# Altium schematic sheet DAP_LINK.SchDoc
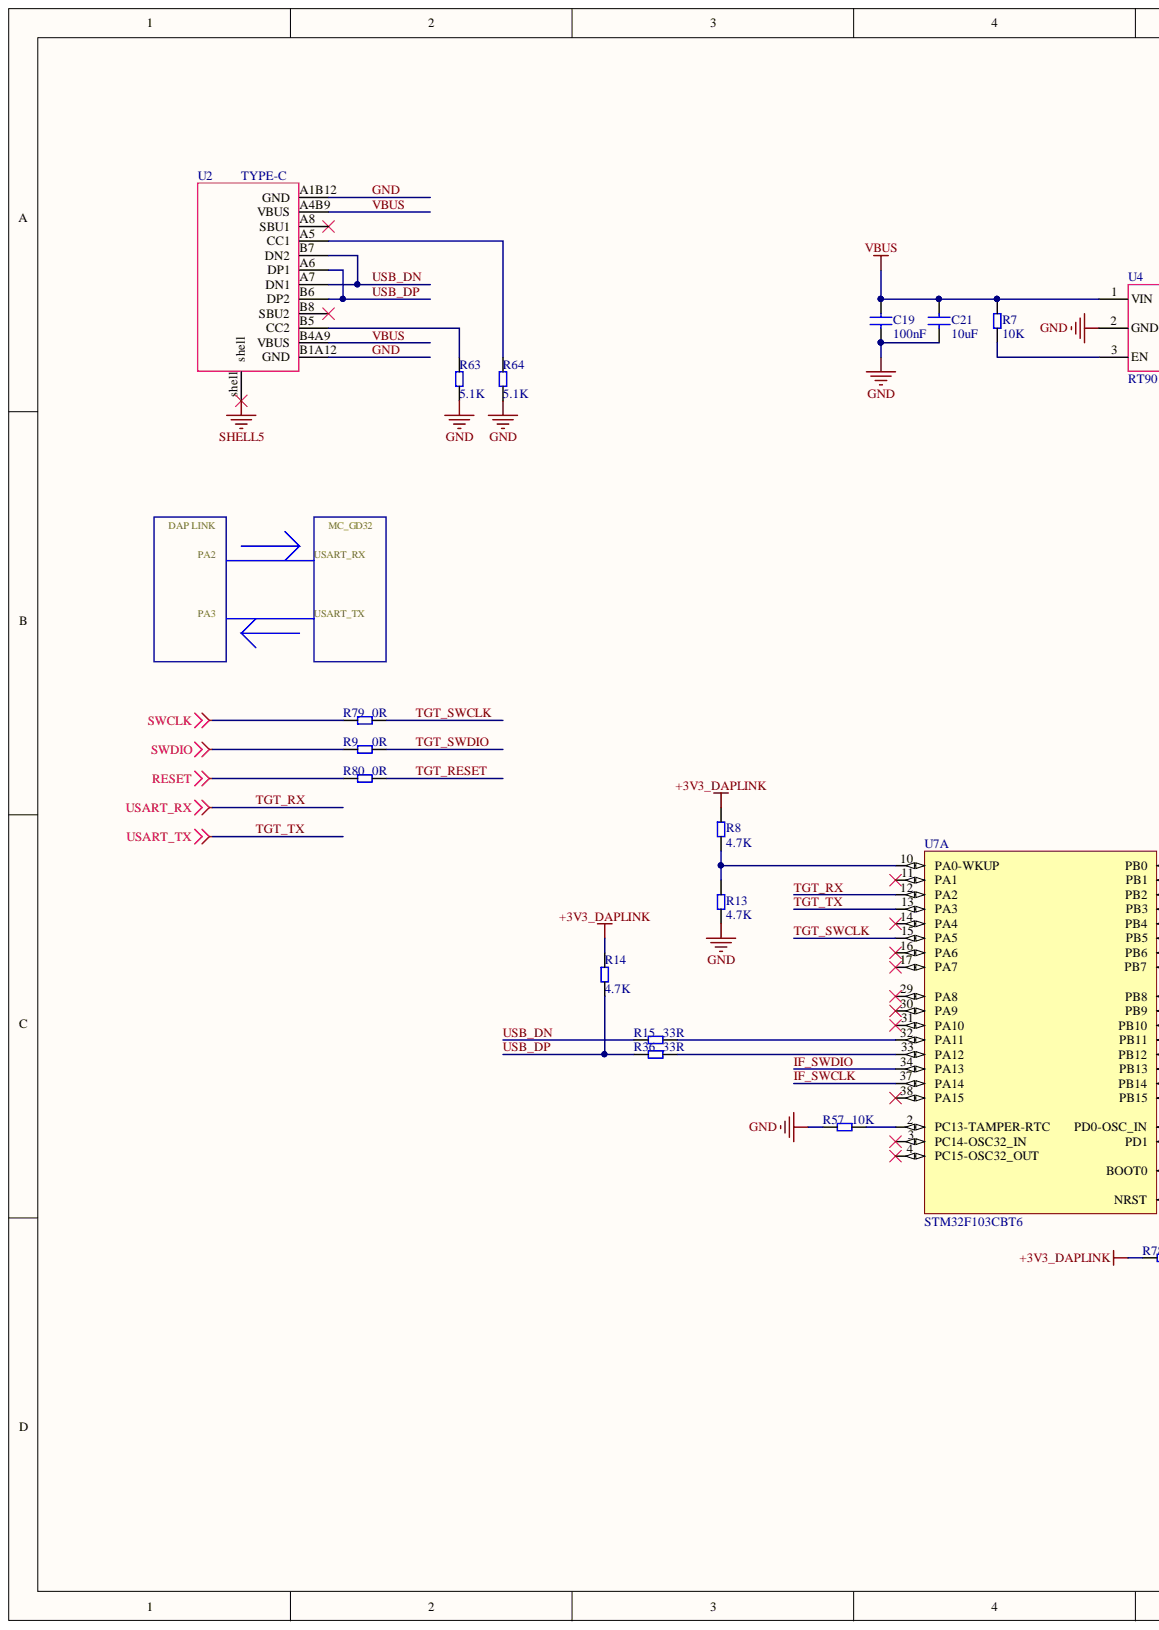
[diagram p0: DAP_LINK.SchDoc, left]
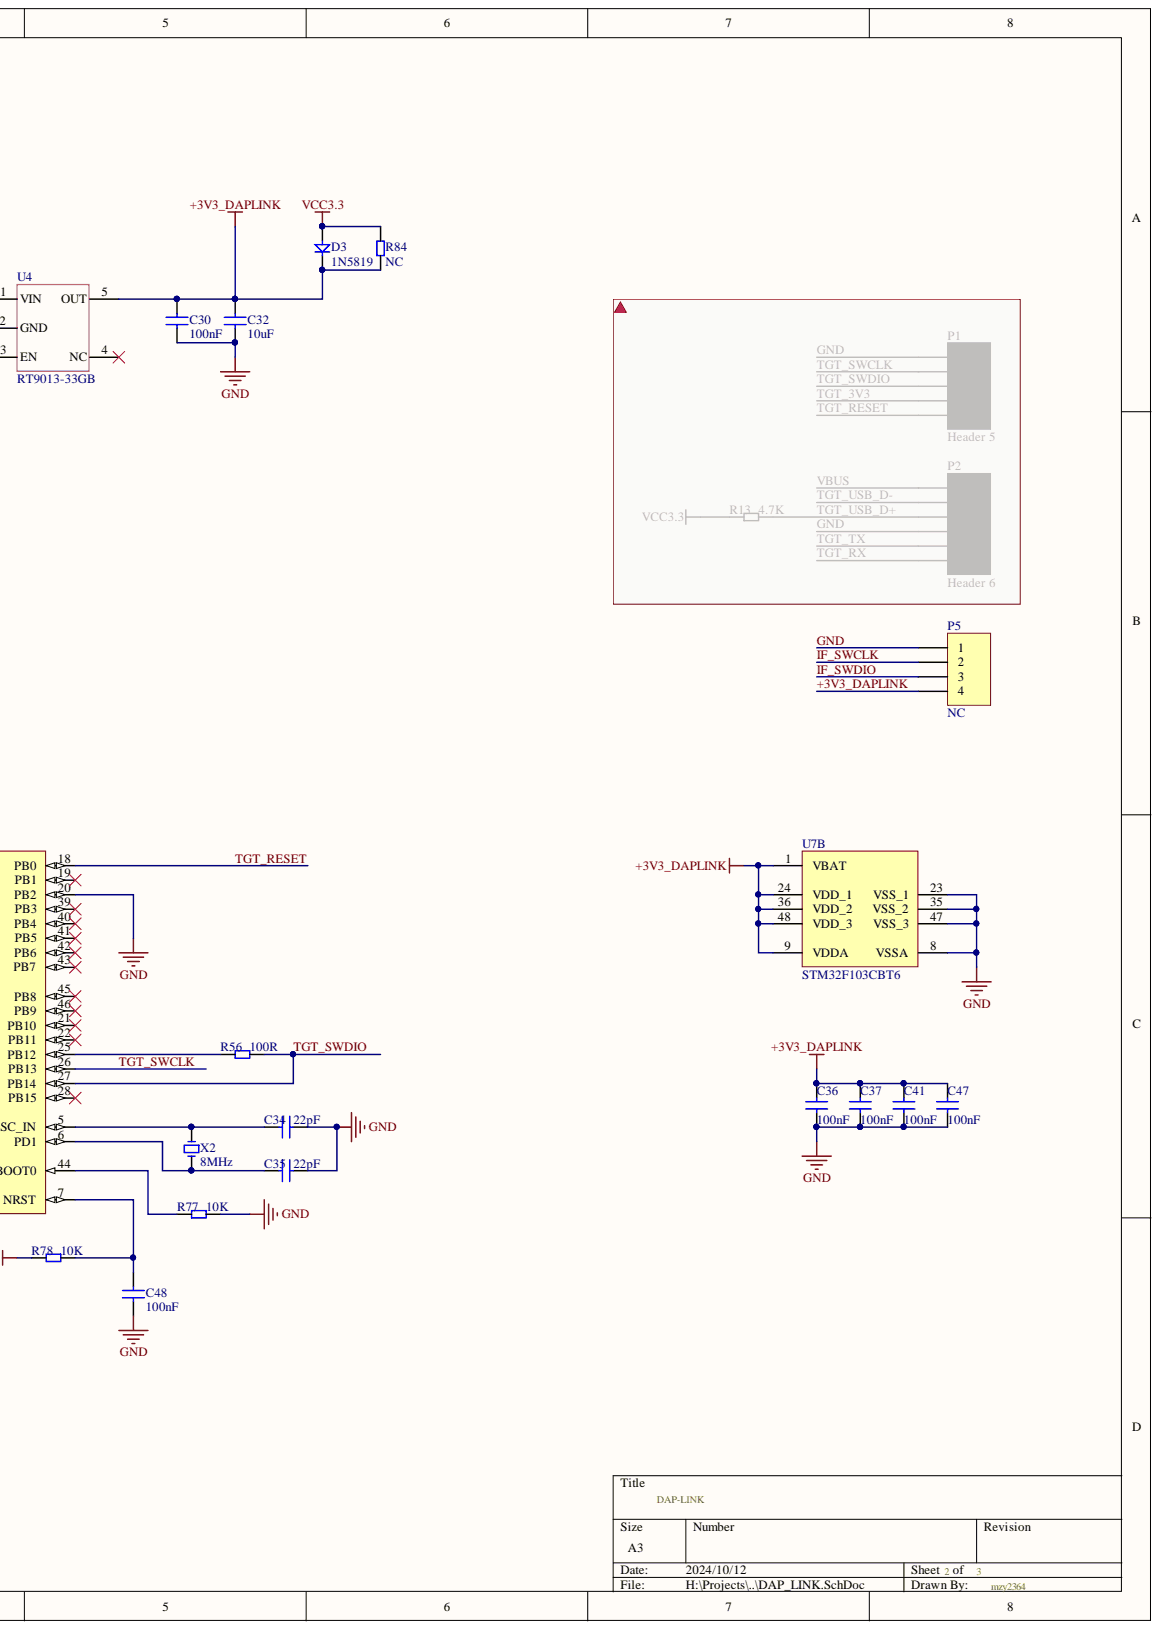
[diagram p1: DAP_LINK.SchDoc, right]

## Parts
C19  100nF  [C0603]
C21  10uF  [C0603]
C30  100nF  [C0603]
C32  10uF  [C0603]
C34  22pF  [C0603]
C35  22pF  [C0603]
C36  100nF  [C0402]
C37  100nF  [C0402]
C41  100nF  [C0402]
C47  100nF  [C0402]
C48  100nF  [C0402]
D3  1N5819  [SOD123]
P5  NC  [HDR1X4]
R7  10K  [RES0402]
R8  4.7K  [RES0402]
R9  0R  [RES0402]
R13  4.7K  [RES0402]
R14  4.7K  [RES0402]
R15  33R  [RES0402]
R36  33R  [RES0402]
R56  100R  [RES0402]
R57  10K  [RES0402]
R63  5.1K  [RES0603]
R64  5.1K  [RES0603]
R77  10K  [RES0402]
R78  10K  [RES0402]
R79  0R  [RES0402]
R80  0R  [RES0402]
R84  NC  [RES0603]
U2  TYPE-C  [USB-TYPE-C-601-WSC]
U4  RT9013-33GB  [SOT23-5]
U7  STM32F103CBT6  [LQFP48-0.5]  (parts A,B)
X2  8MHz  [OSC 5032-2P]

## Nets
RESET: R80.1
SHELL5: U2.shell
SWCLK: R79.1
SWDIO: R9.1
VCC3.3: D3.1 R84.2
+3V3_DAPLINK: C30.2 C32.2 C36.2 C37.2 C41.2 C47.2 D3.2 P5.4 R8.1 R14.1 R78.2 R84.1 U4.5(OUT) U7.1(VBAT) U7.9(VDDA) U7.24(VDD_1) U7.36(VDD_2) U7.48(VDD_3)
GND: C19.1 C21.1 C30.1 C32.1 C34.1 C35.1 C36.1 C37.1 C41.1 C47.1 C48.1 P5.1 R13.2 R57.2 R63.2 R64.2 R77.2 U2.A1B12(GND) U2.B1A12(GND) U4.2(GND) U7.8(VSSA) U7.20(PB2) U7.23(VSS_1) U7.35(VSS_2) U7.47(VSS_3)
IF_SWCLK: P5.2 U7.37(PA14)
IF_SWDIO: P5.3 U7.34(PA13)
TGT_RESET: R80.2 U7.18(PB0)
TGT_RX: U7.12(PA2)
TGT_SWCLK: R79.2 U7.15(PA5) U7.26(PB13)
TGT_SWDIO: R9.2 R56.2 U7.27(PB14)
TGT_TX: U7.13(PA3)
USB_DN: R15.2 U2.A7(DN1) U2.B7(DN2)
USB_DP: R14.2 R36.2 U2.A6(DP1) U2.B6(DP2)
VBUS: C19.2 C21.2 R7.1 U2.A4B9(VBUS) U2.B4A9(VBUS) U4.1(VIN)
NetC34_2: C34.2 U7.5(PD0-OSC_IN) X2.1
NetC35_2: C35.2 U7.6(PD1) X2.2
NetC48_2: C48.2 R78.1 U7.7(NRST)
NetR7_2: R7.2 U4.3(EN)
NetR8_2: R8.2 R13.1 U7.10(PA0-WKUP)
NetR15_1: R15.1 U7.32(PA11)
NetR36_1: R36.1 U7.33(PA12)
NetR56_1: R56.1 U7.25(PB12)
NetR57_1: R57.1 U7.2(PC13-TAMPER-RTC)
NetR63_1: R63.1 U2.B5(CC2)
NetR64_1: R64.1 U2.A5(CC1)
NetR77_1: R77.1 U7.44(BOOT0)

Not connected: U2 A8(SBU1) B8(SBU2); U4 4(NC); U7 3(PC14-OSC32_IN) 4(PC15-OSC32_OUT) 11(PA1) 14(PA4) 16(PA6) 17(PA7) 19(PB1) 21(PB10) 22(PB11) 28(PB15) 29(PA8) 30(PA9) 31(PA10) 38(PA15) 39(PB3) 40(PB4) 41(PB5) 42(PB6) 43(PB7) 45(PB8) 46(PB9)

## Library data of the parts
C19 C21 C30 C32 C34-C37 C41 C47 C48 = CAP: Material: X7R; Precision: 10%; Voltage: 50V; Component Kind: Standard; Library Name: mzy2364.SchLib
D3 = D: Component Kind: Standard; Library Name: mzy2364.SchLib
P5 = Header 4: Header, 4-Pin; Component Kind: Standard; LatestRevisionDate: 17-Jul-2002; LatestRevisionNote: Re-released for DXP Platform.; Library Name: Miscellaneous Connectors.IntLib; Signal Integrity: Connector; Publisher: Altium Limited
R7-R9 R13-R15 R36 R56 R57 R63 R64 R77-R80 R84 = RES: Component Kind: Standard; Library Name: mzy2364.SchLib
U2 = USB TYPE-C: TYPE-C 16pin; Component Kind: Standard; Library Name: mzy2364.SchLib
U4 = RT9013: Component Kind: Standard; Library Name: mzy2364.SchLib
U7 = STM32F103CBT6: ARM; Component Kind: Standard; ComponentLink1Description: 供应商链接; ComponentLink1URL: http://www.szlcsc.com/product/details_8796.html; Library Name: Miscellaneous Devices LC.IntLib; Package: LQFP-48_7x7x05P; Supplier: LC; SuppliersPartNumber: C8304
X2 = XTAL-2P: Component Kind: Standard; Library Name: mzy2364.SchLib
